# Altium schematic sheet Top.SchDoc(Top)
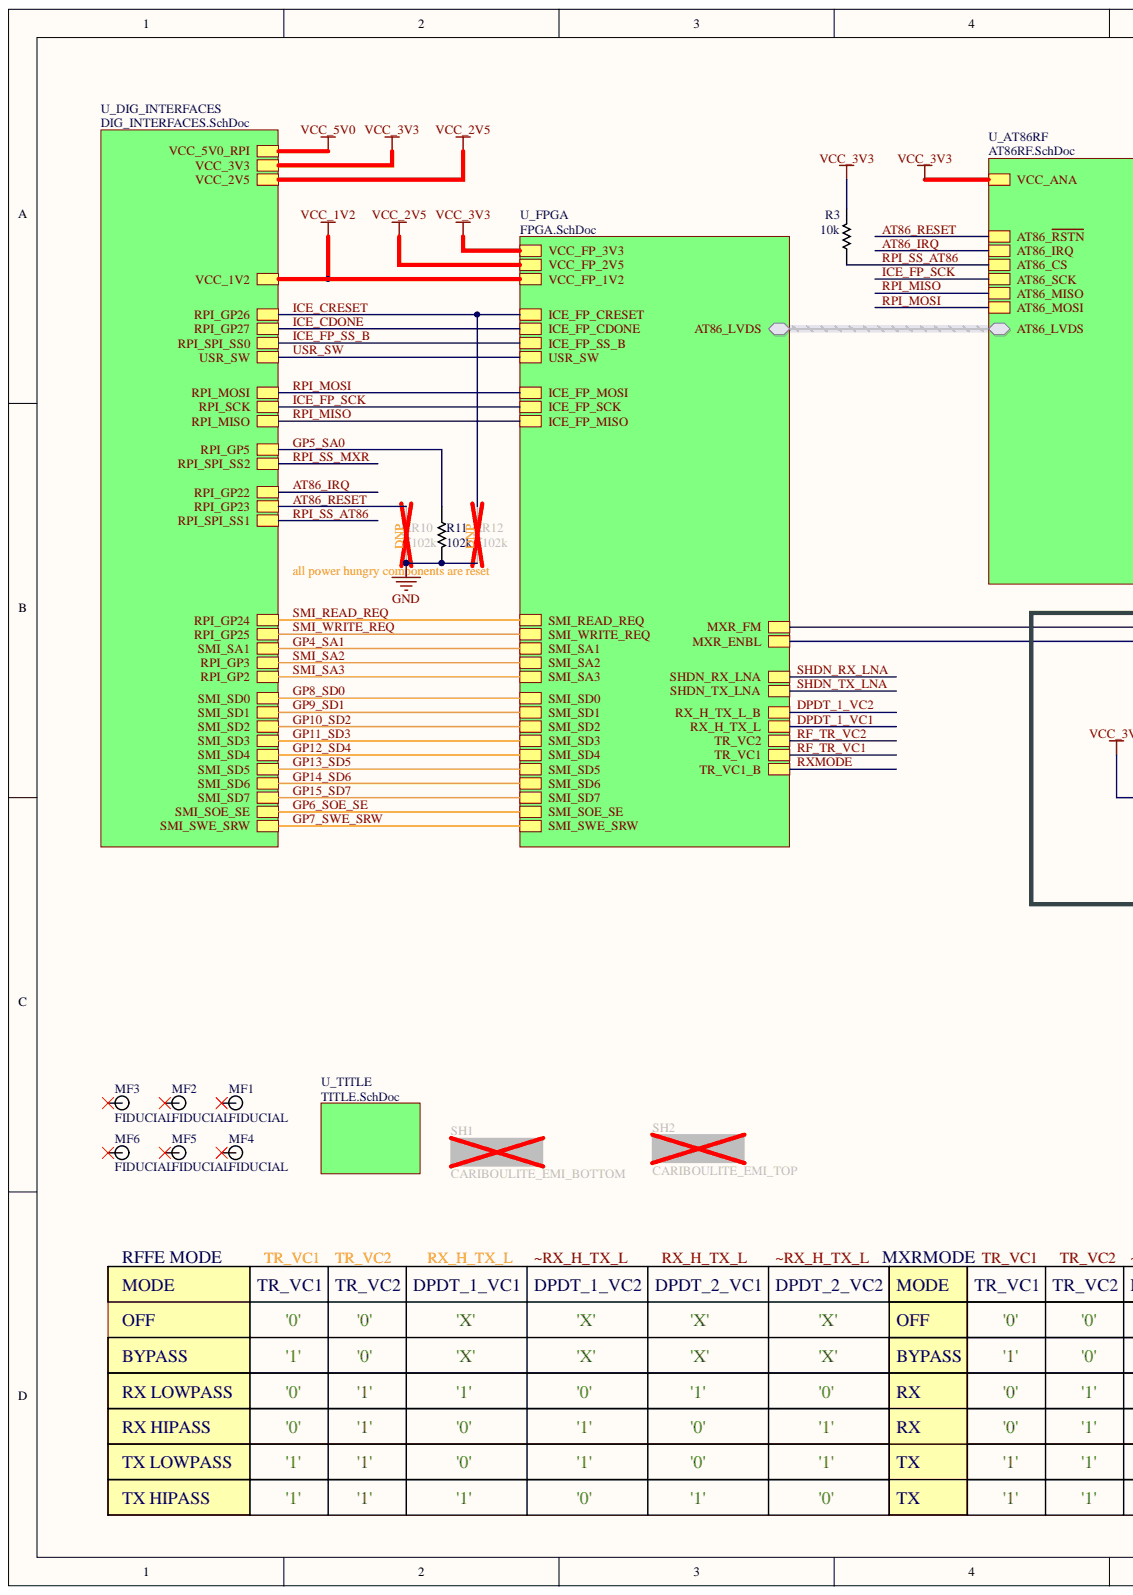
[diagram p0: Top.SchDoc, left]
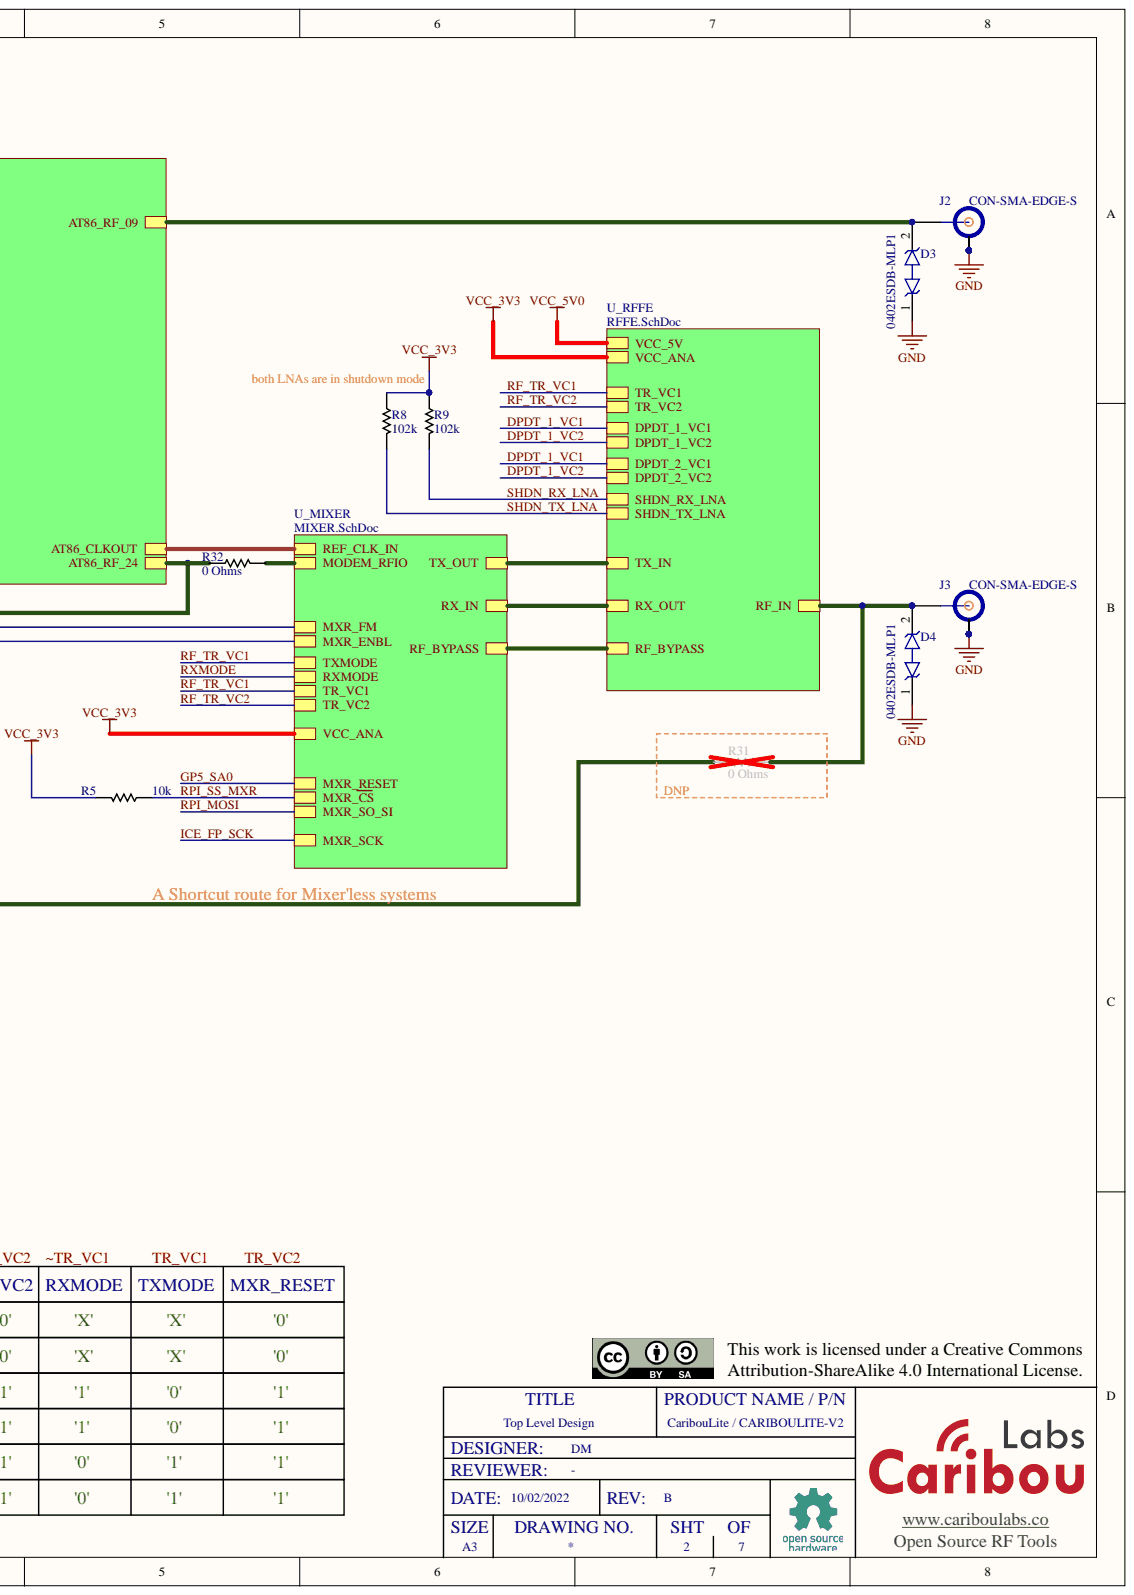
[diagram p1: Top.SchDoc, right]

## Parts
D3  0402ESDB-MLP1  [DIONC1105X44N]
D4  0402ESDB-MLP1  [DIONC1105X44N]
J2  CON-SMA-EDGE-S  [MOLEX_0732511150]
J3  CON-SMA-EDGE-S  [MOLEX_0732511150]
MF1  FIDUCIAL  [FIDUCIAL_1_0_MM]
MF2  FIDUCIAL  [FIDUCIAL_1_0_MM]
MF3  FIDUCIAL  [FIDUCIAL_1_0_MM]
MF4  FIDUCIAL  [FIDUCIAL_1_0_MM]
MF5  FIDUCIAL  [FIDUCIAL_1_0_MM]
MF6  FIDUCIAL  [FIDUCIAL_1_0_MM]
R3  10k  [PANASONIC 0402]
R5  10k  [PANASONIC 0402]
R8  102k  [PANASONIC 0402]
R9  102k  [PANASONIC 0402]
R10  102k  [PANASONIC 0402]
R11  102k  [PANASONIC 0402]
R12  102k  [PANASONIC 0402]
R31  0 Ohms  [PANASONIC 0402]
R32  0 Ohms  [PANASONIC 0402]
SH1  CARIBOULITE_EMI_BOTTOM  [CARIBOULITE_EMI_BOTTOM]  (no BOM)
SH2  CARIBOULITE_EMI_TOP  [CARIBOULITE_EMI_TOP]  (no BOM)

## Nets
GND: D3.1 D4.1 J2.2 J2.3 J2.4 J2.5 J2.6 J2.7 J3.2 J3.3 J3.4 J3.5 J3.6 J3.7 R10.2 R11.2 R12.2
VCC_3V3: R3.2 R5.2 R8.1 R9.1
AT86_RESET: R10.1
ICE_CRESET: R12.1
MXR_RESET: R11.1
RPI_SS_AT86: R3.1
RPI_SS_MXR: R5.1
SHDN_RX_LNA: R9.2
SHDN_TX_LNA: R8.2
NetD3_2: D3.2 J2.1
NetD4_2: D4.2 J3.1 R31.2
NetMF1_1: MF1.1
NetMF2_1: MF2.1
NetMF3_1: MF3.1
NetMF4_1: MF4.1
NetMF5_1: MF5.1
NetMF6_1: MF6.1
NetR31_1: R31.1 R32.1
NetR32_2: R32.2
AT86_CLKOUT:
AT86_IRQ:
AT86_LVDS.AT86_RX09_N:
AT86_LVDS.AT86_RX09_P:
AT86_LVDS.AT86_RX24_N:
AT86_LVDS.AT86_RX24_P:
AT86_LVDS.AT86_RX_CLK_N:
AT86_LVDS.AT86_RX_CLK_P:
AT86_LVDS.AT86_TX_CLK_N:
AT86_LVDS.AT86_TX_CLK_P:
AT86_LVDS.AT86_TX_N:
AT86_LVDS.AT86_TX_P:
ICE_CDONE:
MXR_ENBL:
MXR_FM:
RF_BYPASS:
RPI_MISO:
RPI_MOSI:
RPI_SCK:
RPI_SS_FPGA:
RX_H_TX_L:
RX_H_TX_L_B:
RX_IN:
SMI_READ_REQ:
SMI_SA1:
SMI_SA2:
SMI_SA3:
SMI_SD0:
SMI_SD1:
SMI_SD2:
SMI_SD3:
SMI_SD4:
SMI_SD5:
SMI_SD6:
SMI_SD7:
SMI_SOE_SE:
SMI_SWE_SRW:
SMI_WRITE_REQ:
TR_VC1:
TR_VC1_B:
TR_VC2:
TX_OUT:
USR_SW:
VCC_1V2:
VCC_2V5:
VCC_5V0:

## Library data of the parts
D3 D4 = LXES15AAA1-153: ESD Suppressors / TVS Diodes ESD Protection Device, 0402, Bidirectional, 4V working, 15V breakdown, 0.05pF Cd; Component Kind: Standard; Library Name: CuSiP_Lib.IntLib; Manu. P/N: 0402ESDB-MLP1
J2 J3 = CON-SMA-EDGE-S: RF SMA Connectors / Coaxial Connectors SMA Female PCB Edge Mount; Component Kind: Standard; Library Name: DM_Lib.IntLib; Manu. P/N: CON-SMA-EDGE-S
R3 R5 = Resistor: Thick Film Resistors - SMD 0402 1/16watt 10Kohms 1%; Manu. P/N: CRCW040210K0FKEDC; Power Rating: 62.5 mW (1/16 W); Temp. Ceoff.: 100 PPM/C; Component Kind: Standard; Library Name: CuSiP_Lib.IntLib; Simulation: Model Name; Tolerance: 1%; VALUE: 10k
R8-R12 = Resistor: Thick Film Resistors - SMD 102 kOhms 62.5mW 0402 1%; Manu. P/N: RC0402FR-07102KL; Power Rating: 62.5 mW (1/16 W); Temp. Ceoff.: 100 PPM / C; Component Kind: Standard; Library Name: CuSiP_Lib.IntLib; Simulation: Model Name; Tolerance: 1%; VALUE: 102k
R31 R32 = Resistor: 0 Ohms jumper resistor 0402; Manu. P/N: CRCW04020000Z0EDC; Power Rating: 62.5 mW (1/16 W); Temp. Ceoff.: 0 PPM/C; Tolerance: 1%; Component Kind: Standard; Library Name: CuSiP_Lib.IntLib; Simulation: Model Name; VALUE: 0 Ohms
MF1-MF6 = FIDUCIAL: Component Kind: Net Tie; Library Name: CuSiP_Lib.IntLib; MANU_PN: FIDUCIAL
SH1 = CARIBOULITE_EMI_BOTTOM: Library Name: DM_Lib.IntLib
SH2 = CARIBOULITE_EMI_TOP: Library Name: DM_Lib.IntLib
